# Altium schematic sheet RoBeast_uC.SchDoc(RoBeast_uC)
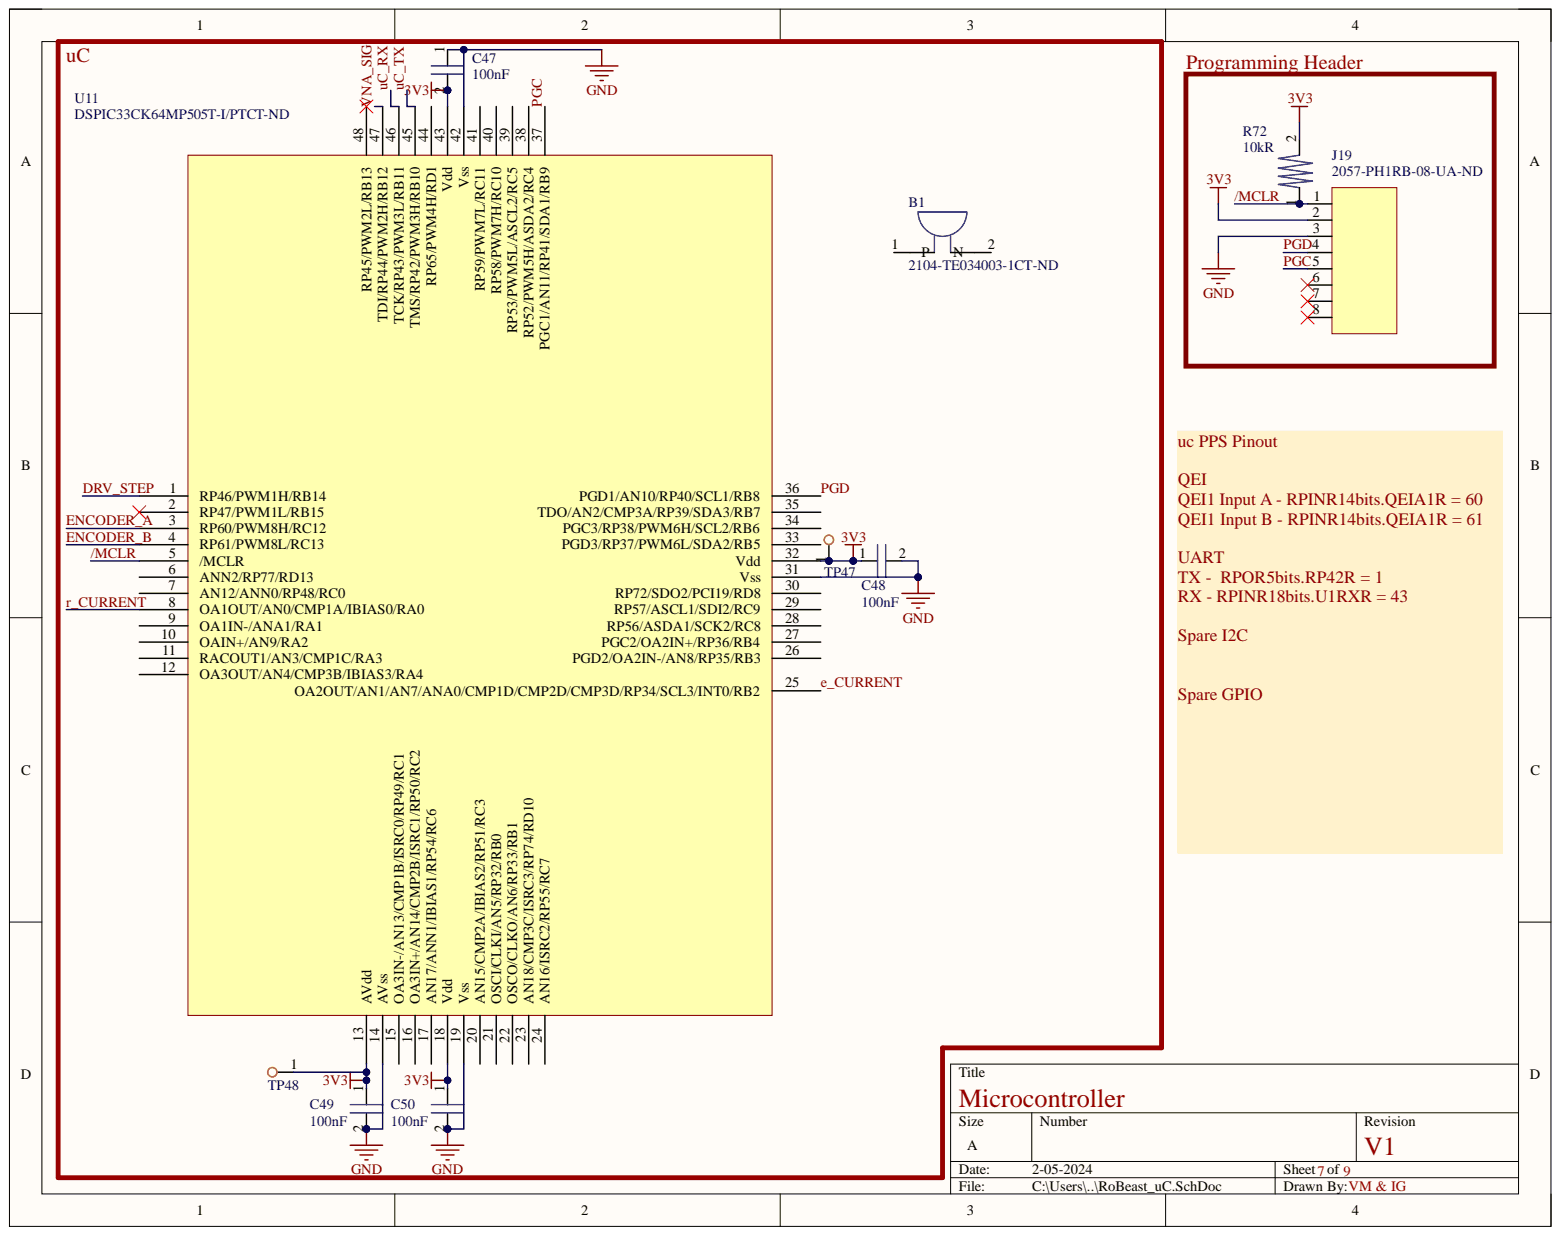
[diagram p0: RoBeast_uC.SchDoc]

## Parts
B1  Supplier Part Number 1: 2104-TE034003-1CT-ND  [TE034003-1]  (hidden comment: Buzzer)
C47  100nF  [0805]
C48  100nF  [0805]
C49  100nF  [0805]
C50  100nF  [0805]
J19  Supplier Part Number 1: 2057-PH1RB-08-UA-ND  [PH1RB-08-UA]  (hidden comment: 8 Pin Connector)
R72  10kR  [0805]
TP47  Test Point  [Test Point]  (value not drawn)
TP48  Test Point  [Test Point]  (value not drawn)
U11  uC  Supplier Part Number: DSPIC33CK64MP505T-I/PTCT-ND  [DSPIC33CK64MP505T-I/PT]

## Nets
3V3: C47.2 C48.1 C49.1 C50.1 J19.2 R72.2 TP47.1 TP48.1 U11.13(AVdd) U11.18(Vdd) U11.32(Vdd) U11.43(Vdd)
GND: C47.1 C48.2 C49.2 C50.2 J19.3 U11.14(AVss) U11.19(Vss) U11.31(Vss) U11.42(Vss)
/MCLR: J19.1 R72.1 U11.5(/MCLR)
DRV_STEP: U11.1(RP46/PWM1H/RB14)
e_CURRENT: U11.25(OA2OUT/AN1/AN7/ANA0/CMP1D/CMP2D/CMP3D/RP34/SCL3/INT0/RB2)
ENCODER_A: U11.3(RP60/PWM8H/RC12)
ENCODER_B: U11.4(RP61/PWM8L/RC13)
PGC: J19.5 U11.37(PGC1/AN11/RP41/SDA1/RB9)
PGD: J19.4 U11.36(PGD1/AN10/RP40/SCL1/RB8)
r_CURRENT: U11.8(OA1OUT/AN0/CMP1A/IBIAS0/RA0)
uC_RX: U11.46(TCK/RP43/PWM3L/RB11)
uC_TX: U11.45(TMS/RP42/PWM3H/RB10)
VNA_SIG: U11.47(TDI/RP44/PWM2H/RB12)

Not connected: B1 1 2; J19 6 7 8; U11 2(RP47/PWM1L/RB15) 6(ANN2/RP77/RD13) 7(AN12/ANN0/RP48/RC0) 9(OA1IN-/ANA1/RA1) 10(OAIN+/AN9/RA2) 11(RACOUT1/AN3/CMP1C/RA3) 12(OA3OUT/AN4/CMP3B/IBIAS3/RA4) 15(OA3IN-/AN13/CMP1B/ISRC0/RP49/RC1) 16(OA3IN+/AN14/CMP2B/ISRC1/RP50/RC2) 17(AN17/ANN1/IBIAS1/RP54/RC6) 20(AN15/CMP2A/IBIAS2/RP51/RC3) 21(OSCI/CLKI/AN5/RP32/RB0) 22(OSCO/CLKO/AN6/RP33/RB1) 23(AN18/CMP3C/ISRC3/RP74/RD10) 24(AN16/ISRC2/RP55/RC7) 26(PGD2/OA2IN-/AN8/RP35/RB3) 27(PGC2/OA2IN+/RP36/RB4) 28(RP56/ASDA1/SCK2/RC8) 29(RP57/ASCL1/SDI2/RC9) 30(RP72/SDO2/PCI19/RD8) 33(PGD3/RP37/PWM6L/SDA2/RB5) 34(PGC3/RP38/PWM6H/SCL2/RB6) 35(TDO/AN2/CMP3A/RP39/SDA3/RB7) 38(RP52/PWM5H/ASDA2/RC4) 39(RP53/PWM5L/ASCL2/RC5) 40(RP58/PWM7H/RC10) 41(RP59/PWM7L/RC11) 44(RP65/PWM4H/RD1) 48(RP45/PWM2L/RB13)

## Library data of the parts
B1 = TE034003-1: Electro-mechanical Transducer; Manufacturer: DB Unlimited; Category: Audio Products; Component Kind: Standard; Manufacturer Part Number: TE034003-1; Pricing 1: 1=2.3, 10=1.911, 25=1.7652, 50=1.659, 100=1.5568, 250=1.44984, 500=1.33496, 1000=1.29987 (USD); RoHS: No; Stock 1: 5468; Supplier 1: Digikey; Library Name: RoBeast_Schematic_Library.SchLib
C47-C50 = C0805C104J5RAC7800: Ceramic Capacitor, Multilayer, Ceramic, 50V, 5% +Tol, 5% -Tol, X7R, 15% TC, 0.1uF, Surface Mount, 0805; Manufacturer: KEMET; Manufacturer Part Number: C0805C104J5RACTU; Multilayer: Yes; Capacitance: 100nF; Capacitor Type: Ceramic; Category: Ceramic Capacitors; Component Kind: Standard; ComponentLink1Description: http://capacitoredge.kemet.com:80/capedge2/intellispec.jsp?pn=C0805C104J5RACTU&displayPN=C0805C104J5RACTU&src-supplier=IHS+Markit; ComponentLink1URL: http://capacitoredge.kemet.com:80/capedge2/intellispec.jsp?pn=C0805C104J5RACTU&displayPN=C0805C104J5RACTU&src-supplier=IHS+Markit; ComponentLink2Description: https://datasheet.ciiva.com/7842/1732721-7842179.pdf?src-supplier=Element14; ComponentLink2URL: https://datasheet.ciiva.com/7842/1732721-7842179.pdf?src-supplier=Element14; ComponentLink3Description: https://datasheet.ciiva.com/28682/1851736-28682223.pdf?src-supplier=Element14; ComponentLink3URL: https://datasheet.ciiva.com/28682/1851736-28682223.pdf?src-supplier=Element14; ComponentLink4Description: https://datasheet.ciiva.com/7258/1828800-7258413.pdf?src-supplier=Newark; ComponentLink4URL: https://datasheet.ciiva.com/7258/1828800-7258413.pdf?src-supplier=Newark; ComponentLink5Description: https://datasheet.ciiva.com/14589/70095383-14589015.pdf?src-supplier=Allied+Electronics; ComponentLink5URL: https://datasheet.ciiva.com/14589/70095383-14589015.pdf?src-supplier=Allied+Electronics; ComponentLink6Description: https://datasheet.ciiva.com/5417/kem-c1002-x7r-smd-5417352.pdf?src-supplier=Mouser; ComponentLink6URL: https://datasheet.ciiva.com/5417/kem-c1002-x7r-smd-5417352.pdf?src-supplier=Mouser; ComponentLink7Description: https://datasheet.ciiva.com/39122/docfinderpartnumberc0402c102k5ractu-39122924.pdf?src-supplier=Onlinecomponents.com; ComponentLink7URL: https://datasheet.ciiva.com/39122/docfinderpartnumberc0402c102k5ractu-39122924.pdf?src-supplier=Onlinecomponents.com; Dielectric Material: Ceramic; JESD-609 Code: e3; Max Operating Temperature: 125°C; Min Operating Temperature: -55°C; Mount: Surface Mount; Negative Tolerance: 5%; Number of Pins: 2; Package Shape: Rectangular; Packaging: Tape and Reel; Positive Tolerance: 5%; Pricing 1: 1=0.18, 10=0.122, 50=0.0732, 100=0.0576, 500=0.04252, 1000=0.03519 (USD); Rated DC Voltage (URdc): 50V; Reach SVHC Compliant: Yes; RoHS: Yes; RoHS Compliant: Yes; Size Code: 0805; Stock 1: 605061; Supplier 1: Digikey; Supplier Part Number 1: 399-C0805C104J5RAC7800CT-ND; Temperature Characteristics Code: X7R; Terminal Finish: Nickel; Termination: Wraparound; Library Name: RoBeast_Schematic_Library.SchLib
J19 = PH1RB-08-UA: Conn Unshrouded Header HDR 8 POS 2.54mm Solder RA Thru-Hole; Manufacturer: Adam Equipment; Category: Connectors; Component Kind: Standard; Manufacturer Part Number: PH1RB-08-UA; Pricing 1: 1=0.23, 10=0.196, 100=0.1505, 500=0.12738, 1000=0.10423, 5000=0.09265, 10000=0.08396 (USD); RoHS: No; Stock 1: 10117; Supplier 1: Digikey; Library Name: RoBeast_Schematic_Library.SchLib
R72 = RMCF0805FT10K0: Fixed Resistor, Metal Glaze/thick Film, 0.125W, 10000ohm, 150V, 1% +/-Tol, 100ppm/Cel, Surface Mount, 0805; Manufacturer: Stackpole Electronics; Package Height: 0.5mm; Package Length: 2mm; Package Width: 1.25mm; Rated Power Dissipation (P): 125mW; Rated Temperature: 70°C; Reference Standard: AEC-Q200; Temperature Coefficient: 100ppm/°C; Category: Chip SMD Resistors; Component Kind: Standard; ComponentLink1Description: https://datasheet.ciiva.com:443/pdfs/VipMasterIC/IC/SEIE/SEIE-S-A0009541969/SEIE-S-A0009541969-1.pdf?src-supplier=IHS+Markit; ComponentLink1URL: https://datasheet.ciiva.com:443/pdfs/VipMasterIC/IC/SEIE/SEIE-S-A0009541969/SEIE-S-A0009541969-1.pdf?src-supplier=IHS+Markit; ComponentLink2Description: https://datasheet.ciiva.com/15193/getdatasheetpartid-362046-15193525.pdf?src-supplier=Verical; ComponentLink2URL: https://datasheet.ciiva.com/15193/getdatasheetpartid-362046-15193525.pdf?src-supplier=Verical; Construction: Rectangular; JESD-609 Code: e3; Manufacturer Part Number: RMCF0805FT10K0; Max Operating Temperature: 155°C; Min Operating Temperature: -55°C; Mount: Surface Mount; Number of Pins: 2; Package Shape: Rectangular; Package Style: SMTMeter; Packaging: Tape and Reel; Pricing 1: 1=0.1, 10=0.02, 50=0.0124, 100=0.0103, 500=0.007, 1000=0.00601 (USD); Reach SVHC Compliant: Yes; Resistance: 10kR; Resistor Type: FIXED RESISTOR; RoHS: Yes; RoHS Compliant: Yes; Size Code: 0805; Stock 1: 3602197; Supplier 1: Digikey; Supplier Part Number 1: RMCF0805FT10K0CT-ND; Technology: METAL GLAZE/THICK FILM; Terminal Finish: Nickel; Termination: Wraparound; Tolerance: 1%; Working Voltage: 150V; Library Name: RoBeast_Schematic_Library.SchLib
U11 = DSPIC33CK64MP505T-I/PT: 16-Bit Size, 0-Ext Bit, 64MHz, CMOS, PQFP48; Manufacturer: Microchip; Category: Microcontrollers; Component Kind: Standard; ComponentLink1Description: https://datasheet.ciiva.com:443/pdfs/VipMasterIC/IC/MCHP/MCHP-S-A0007771634/MCHP-S-A0007771634-1.pdf?src-supplier=IHS+Markit; ComponentLink1URL: https://datasheet.ciiva.com:443/pdfs/VipMasterIC/IC/MCHP/MCHP-S-A0007771634/MCHP-S-A0007771634-1.pdf?src-supplier=IHS+Markit; ComponentLink2Description: http://www.microchip.com/mymicrochip/filehandler.aspx?ddocname=en606233&src-supplier=Digikey; ComponentLink2URL: http://www.microchip.com/mymicrochip/filehandler.aspx?ddocname=en606233&src-supplier=Digikey; Manufacturer Part Number: DSPIC33CK64MP505T-I/PT; Pricing: 1=3.75, 25=3.44, 100=3.12 (USD); RoHS: No; Stock: 1600; Supplier: Digikey; Library Name: RoBeast_Schematic_Library.SchLib
TP47 TP48 = Test Point: Component Kind: Standard; Library Name: RoBeast_Schematic_Library.SchLib
